# Altium schematic sheet NanoD1_Power.SchDoc
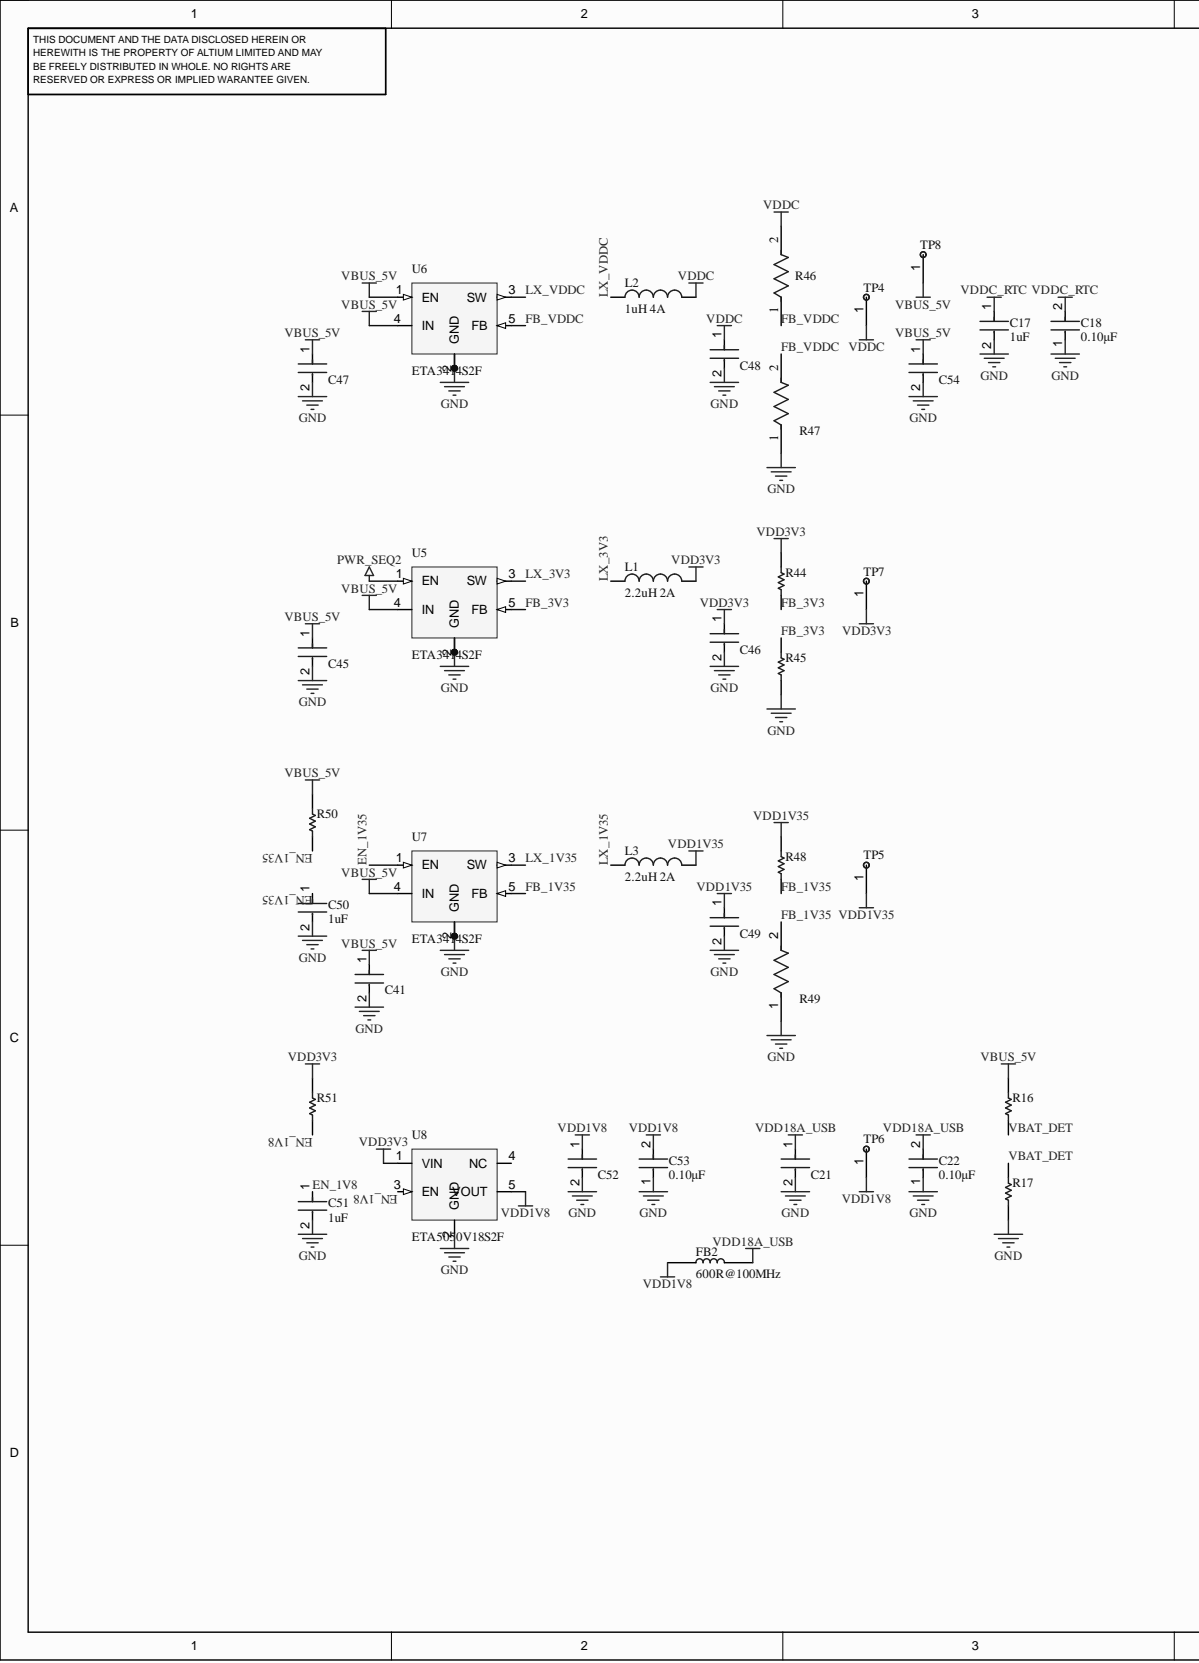
[diagram p0: NanoD1_Power.SchDoc, left]
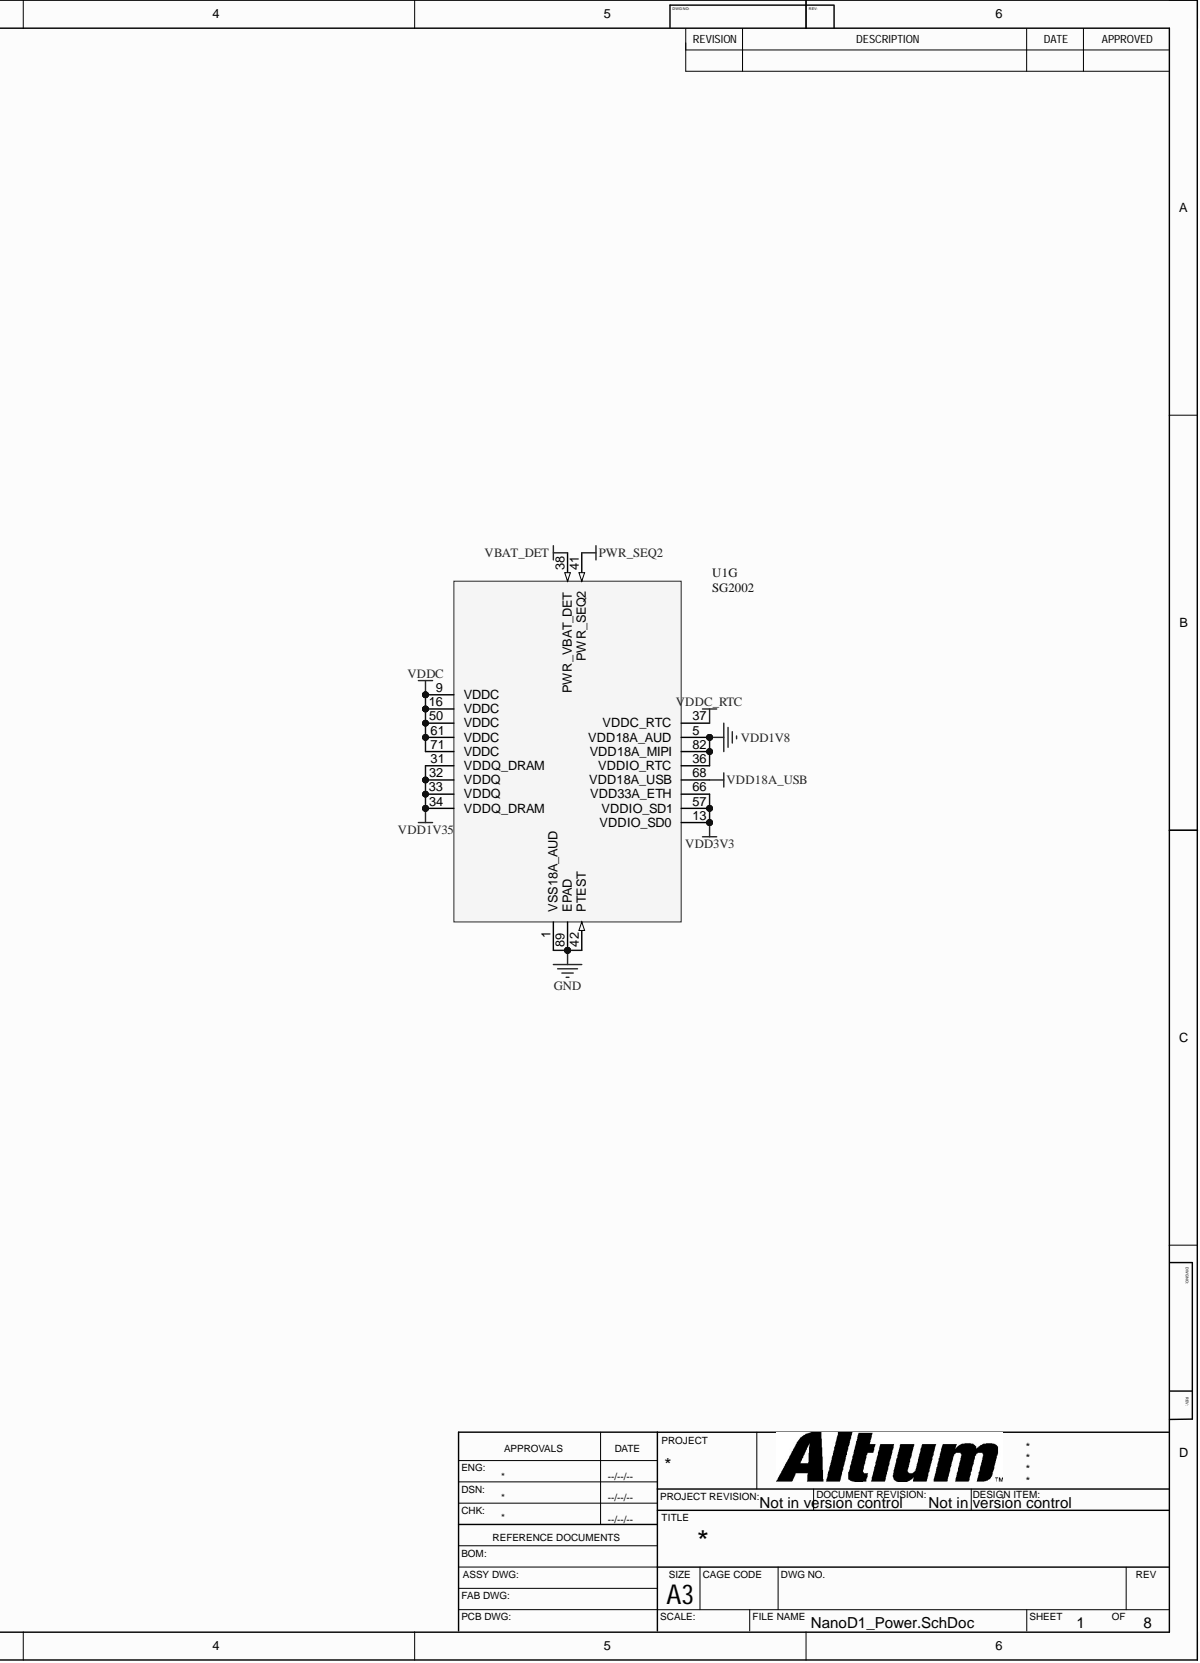
[diagram p1: NanoD1_Power.SchDoc, right]

## Parts
C17  1uF  [FP-GRM15-0_1-IPC_B]
C18  value 0.10μF  Capacitance: 0.10μF  [FP-GRM15-MFG]  (hidden comment: 100nF)
C21  10uF  [FP-GRM188-0_15-IPC_B]  (value not drawn)
C22  value 0.10μF  Capacitance: 0.10μF  [FP-GRM15-MFG]  (hidden comment: 100nF)
C41  22uF  [FP-GRM21B-0_15-MFG]  (value not drawn)
C45  22uF  [FP-GRM21B-0_15-MFG]  (value not drawn)
C46  22uF  [FP-GRM188-0_2-IPC_C]  (value not drawn)
C47  22uF  [FP-GRM21B-0_15-MFG]  (value not drawn)
C48  22uF  [FP-GRM188-0_2-IPC_C]  (value not drawn)
C49  22uF  [FP-GRM188-0_2-IPC_C]  (value not drawn)
C50  1uF  [FP-GRM15-0_1-IPC_B]
C51  1uF  [FP-GRM15-0_1-IPC_B]
C52  4.7uF  [FP-GRM155-0_15-MFG]  (value not drawn)
C53  value 0.10μF  Capacitance: 0.10μF  [FP-GRM15-MFG]  (hidden comment: 100nF)
C54  22uF  [FP-GRM21B-0_15-MFG]  (value not drawn)
FB2  600R@100MHz  [INDC1608X95N]
L1  2.2uH 2A  [FP-DFE252010C-MFG]
L2  value 1uH 4A  [FP-DFE252010C-MFG]  (hidden comment: 1.0uH 4A)
L3  2.2uH 2A  [FP-DFE252010C-MFG]
R16  30K 1%  [RESC1005X40X25ML05T10]  (value not drawn)
R17  10K 1%  [RESC1005X40X25ML05T10]  (value not drawn)
R44  100K 1%  [RESC1005X40X25ML05T10]  (value not drawn)
R45  22K6 1%  [RESC1005X40X25ML05T10]  (value not drawn)
R46  11K8 1%  [FP-RC0402-0_4-MFG]  (value not drawn)
R47  20K 1%  [FP-RC0402-0_4-MFG]  (value not drawn)
R48  15K 1%  [RESC1005X40X25ML05T10]  (value not drawn)
R49  12K 1%  [FP-RC0402-0_4-MFG]  (value not drawn)
R50  33K  [RESC1005X40X25ML05T10]  (value not drawn)
R51  33K  [RESC1005X40X25ML05T10]  (value not drawn)
TP4  TP  [TP-1.5mm]
TP5  TP  [TP-1.5mm]
TP6  TP  [TP-1.5mm]
TP7  TP  [TP-1.5mm]
TP8  TP  [TP-1.5mm]
U1  SG2002  [QFN88-9x9-0.35]  (part G)
U5  ETA3414S2F  [SOT23-5]
U6  ETA3414S2F  [SOT23-5]
U7  ETA3414S2F  [SOT23-5]
U8  ETA5050V18S2F  [SOT23-5]

## Nets
GND: C17.2 C18.1 C21.2 C22.1 C41.2 C45.2 C46.2 C47.2 C48.2 C49.2 C50.2 C51.2 C52.2 C53.1 C54.2 R17.1 R45.1 R47.1 R49.1 U1.1(VSS18A_AUD) U1.42(PTEST) U1.89(EPAD) U5.2(GND) U6.2(GND) U7.2(GND) U8.2(GND)
PWR_SEQ2: U1.41(PWR_SEQ2) U5.1(EN)
VBUS_5V: C41.1 C45.1 C47.1 C54.1 R16.2 R50.2 TP8.1 U5.4(IN) U6.1(EN) U6.4(IN) U7.4(IN)
VDD1V8: C52.1 C53.2 FB2.1 TP6.1 U1.5(VDD18A_AUD) U1.36(VDDIO_RTC) U1.82(VDD18A_MIPI) U8.5(VOUT)
VDD1V35: C49.1 L3.2 R48.2 TP5.1 U1.31(VDDQ_DRAM) U1.32(VDDQ) U1.33(VDDQ) U1.34(VDDQ_DRAM)
VDD3V3: C46.1 L1.2 R44.2 R51.2 TP7.1 U1.13(VDDIO_SD0) U1.57(VDDIO_SD1) U1.66(VDD33A_ETH) U8.1(VIN)
VDD18A_USB: C21.1 C22.2 FB2.2 U1.68(VDD18A_USB)
VDDC: C48.1 L2.2 R46.2 TP4.1 U1.9(VDDC) U1.16(VDDC) U1.50(VDDC) U1.61(VDDC) U1.71(VDDC)
VDDC_RTC: C17.1 C18.2 U1.37(VDDC_RTC)
EN_1V8: C51.1 R51.1 U8.3(EN)
EN_1V35: C50.1 R50.1 U7.1(EN)
FB_1V35: R48.1 R49.2 U7.5(FB)
FB_3V3: R44.1 R45.2 U5.5(FB)
FB_VDDC: R46.1 R47.2 U6.5(FB)
LX_1V35: L3.1 U7.3(SW)
LX_3V3: L1.1 U5.3(SW)
LX_VDDC: L2.1 U6.3(SW)
VBAT_DET: R16.1 R17.2 U1.38(PWR_VBAT_DET)

Not connected: U8 4(NC)

## Library data of the parts
C17 C50 C51 = CMP-2008-04556-2: CAP CER 1UF 10V X5R 0402; Manufacturer: Murata; Manufacturer Part Number: GRM155R61A105KE15D; Material: Ceramic; Temperature Coefficient: 15%; Tolerance: 10%; Automotive: No; Capacitance: 1uF; Category: Cap; Component Kind: Standard; ComponentLink2Description: Datasheet; ComponentLink2URL: https://search.murata.co.jp/Ceramy/image/img/A01X/G101/ENG/GRM155R61A105KE15-01A.pdf; Device Class L1: Passive Components; Device Class L2: Capacitors; Device Class L3: Ceramic Capacitors; Digikey Description: CAP CER 1UF 10V X5R 0402; Height: 0.55mm; Ipc Land Pattern Name: CAPC10050X50; Lead Free: Yes; Library Name: GRM155R61A105KE15D.IntLib; Mouser Description: 0402 1 uF 10 V ±10% Tolerance X5R Surface Mount Multilayer Ceramic Capacitor; Octopart: https://octopart.com/grm155r61a105ke15d-murata-197442; Package: GRM15-0.1; RoHS: TRUE; Temperature: -55°C to +85°C; Temperature Characteristic: X5R; Temperature Range High: +85°C; Temperature Range Low: -55°C; Value: 1uF; Voltage Rating: 10V
C18 C22 C53 = CMP-2100-03641-2: CAP CER 0.1UF 16V X7R 0402; Manufacturer: Murata; Manufacturer Part Number: GRM155R71C104KA88D; Material: Ceramic; Standoff Height: 0mm; Tolerance: 10%; Voltage Rating: 16Vdc; Category: Cap; Component Kind: Standard; ComponentLink2Description: Datasheet; ComponentLink2URL: https://search.murata.co.jp/Ceramy/image/img/A01X/G101/ENG/GRM155R71H471KA01-01A.pdf; Device Class L1: Passive Components; Device Class L2: Capacitors; Device Class L3: Ceramic Capacitors; Digikey Description: CAP CER 0.1UF 16V X7R 0402; Height: 0.55mm; Ipc Land Pattern Name: CAPC10050X219; Lead Free: yes; Library Name: GRM155R71C104KA88D.IntLib; Mouser Description: 0402 0.1 uF 16V ±10 % Tolerance X7R SMT Multilayer Ceramic Capacitor; Octopart: https://octopart.com/grm155r71c104ka88d-murata-196880; Package: GRM15; RoHS: TRUE; Temperature: -55°C to +125°C; Temperature Characteristic: X7R; Temperature Range High: +125°C; Temperature Range Low: -55°C
C21 = CMP-06035-003612-1: Chip Multilayer Ceramic Capacitors for General Purpose, 0603, 10uF, X5R, 15%, 20%, 10V; Capacitance: 10uF; Case Code (Imperial): 0603; Case Code (Metric): 1608; Case/Package: 0603; Component Kind: Standard; Depth: 0.8mm; Dielectric: X5R; Height: 0.8mm; Lead Free: Lead Free; Length: 1.6mm; Library Name: GRM188R61A106ME69D.IntLib; Material: Ceramic; Max Operating Temperature: 85°C; Min Operating Temperature: -55°C; Mount: Surface Mount; Package Quantity: 4000; Packaging: Tape and Reel; Termination: SMD/SMT; Thickness: 0.035inch; Tolerance: 20%; Voltage Rating: 10V; Voltage Rating (DC): 10V; Weight: 0.000222oz; Width: 0.8mm
C41 C45 C47 C54 = CMP-2000-06340-2: Chip Multilayer Ceramic Capacitors for General Purpose, 0805, 22uF, X5R, 15%, 20%, 10V; Capacitance: 22uF; Case Code (Imperial): 0805; Case Code (Metric): 2012; Case/Package: 0805; Component Kind: Standard; Depth: 1.25mm; Dielectric: X5R; Height: 1.25mm; Lead Free: Lead Free; Length: 2mm; Library Name: GRM21BR61A226ME51L.IntLib; Max Operating Temperature: 85°C; Min Operating Temperature: -55°C; Mount: Surface Mount; Package Quantity: 3000; Packaging: Tape and Reel; Radiation Hardening: No; REACH SVHC: No SVHC; Termination: SMD/SMT; Thickness: 0.055inch; Tolerance: 20%; Voltage Rating: 10V; Voltage Rating (DC): 10V; Weight: 0.000335oz; Width: 0.049inch
C46 C48 C49 = CMP-2000-06337-3: Chip Multilayer Ceramic Capacitors for General Purpose, 0603, 22uF, X5R, 15%, 20%, 10V; Capacitance: 22uF; Case Code (Imperial): 0603; Case Code (Metric): 1608; Case/Package: 0603; Component Kind: Standard; Depth: 0.8mm; Dielectric: X5R; Height: 0.8mm; Lead Free: Lead Free; Length: 1.6mm; Library Name: GRM188R61A226ME15D.IntLib; Material: Ceramic; Max Operating Temperature: 85°C; Min Operating Temperature: -55°C; Mount: Surface Mount; Package Quantity: 4000; Packaging: Tape and Reel; REACH SVHC: No SVHC; Termination: SMD/SMT; Thickness: 0.039inch; Tolerance: 20%; Voltage Rating: 10V; Voltage Rating (DC): 10V; Weight: 0.000222oz; Width: 0.8mm
C52 = CMP-06035-003573-1: Chip Multilayer Ceramic Capacitors for General Purpose, 0402, 4.7uF, X5R, 15%, 20%, 10V; Capacitance: 4.7uF; Case Code (Imperial): 0402; Case Code (Metric): 1005; Case/Package: 0402; Component Kind: Standard; Library Name: GRM155R61A475MEAAD.IntLib; Max Operating Temperature: 85°C; Min Operating Temperature: -55°C; Mount: Surface Mount; Packaging: Tape and Reel; Tolerance: 20%; Voltage Rating: 10V
FB2 = MPZ1608S601ATA00: 600 Ohms @ 100 MHz 1 Power Line Ferrite Bead 0603 (1608 Metric) 1A 150mOhm; MF: TDK; Check_prices: https://www.snapeda.com/parts/MPZ1608S601ATA00/TDK/view-part/?ref=eda; Component Kind: Standard; Library Name: MPZ1608S601ATA00.IntLib; MP: MPZ1608S601ATA00; Package: 0603 TDK; SnapEDA_Link: https://www.snapeda.com/parts/MPZ1608S601ATA00/TDK/view-part/?ref=snap; Value: 600R@100MHz
L1-L3 = Inductor: Code_IEC: 1005; Code_JEITA: 0402; Component Kind: Standard; Description: Inductor; LatestRevisionDate: 29-May-2009; LatestRevisionNote: IPC-7351 Footprint Added.; Library Name: Miscellaneous Devices.IntLib; PackageDescription: Chip Inductor; PackageReference: 0402-A; Published: 8-Jun-2000; Signal Integrity: Inductor; Simulation: INDUCTOR; Publisher: Altium Limited
  L1 L3: Value: 2.2uH 2A
R16 = CMP-2002-07602-1: Manufacturer: Yageo / Phycomp; Part Number: RC0402FR-0730KL; Component Kind: Standard; Library Name: RC0402FR-0730KL.IntLib
R17 = CMP-2002-07353-1: Manufacturer: Yageo / Phycomp; Part Number: RC0402FR-0710KL; Component Kind: Standard; Library Name: RC0402FR-0710KL.IntLib
R44 = CMP-2002-07343-1: Manufacturer: Yageo / Phycomp; Part Number: RC0402FR-07100KL; Component Kind: Standard; Library Name: RC0402FR-07100KL.IntLib
R45 = CMP-2002-07516-1: Manufacturer: Yageo; Part Number: RC0402FR-0722K6L; Component Kind: Standard; Library Name: RC0402FR-0722K6L.IntLib
R46 = CMP-2002-07909-2: NexarPartId: 9293467; Max Power Dissipation: 63mW; Resistance: 11.8kΩ; Temperature Coefficient: 100ppm/°C; Voltage Rating: 50V; Case Code (Imperial): 0402; Case Code (Metric): 1005; Case/Package: 0402; Component Kind: Standard; Composition: Thick Film; Contact Plating: Lead, Tin; Depth: 500µm; Features: Moisture Resistant; Height: 400µm; Lead Free: Lead Free; Length: 1mm; Library Name: RC0402FR-0711K8L.IntLib; Max Operating Temperature: 155°C; Military Standard: Not; Min Operating Temperature: -55°C; Number of Terminations: 2; Packaging: Tape & Reel; Power Rating: 63mW; Radiation Hardening: No; RoHS: Compliant; Schedule B: 8533210045, 8533210045|8533210045, 8533210045|8533210045|8533210045, 8533210045|8533210045|8533210045|8533210045; Termination: SMD/SMT; Tolerance: 1%; Voltage Rating (DC): 50V; Weight: 652.039032µg; Width: 508µm
R47 = CMP-2002-07501-2: NexarPartId: 39794396; Max Power Dissipation: 63mW; Power Rating: 62.5mW; Resistance: 20kΩ; Temperature Coefficient: 100ppm/°C; Voltage Rating: 50V; Case Code (Imperial): 0402; Case Code (Metric): 1005; Case/Package: 0402; Component Kind: Standard; Composition: Thick Film; Depth: 500µm; Features: Moisture Resistant; Height: 350µm; Lead Free: Lead Free; Length: 1mm; Library Name: RC0402FR-0720KL.IntLib; Max Operating Temperature: 155°C; Military Standard: Not; Min Operating Temperature: -55°C; Number of Pins: 2; Number of Terminations: 2; Packaging: Tape & Reel; REACH SVHC: No SVHC; RoHS: Compliant; Schedule B: 8533210045, 8533210045|8533210045|8533210045|8533210045|8533210045|8533210045|8533210045|8533210045|8533210045|8533210045|8533210045|8533210045|8533210045|8533210045|8533210045|8533210045|8533210045|8533210045|8533210045|8533210045|8533210045|8533210045|8533210045|85; Termination: SMD/SMT; Tolerance: 1%; Voltage Rating (DC): 50V; Width: 500µm
R48 = CMP-2002-07410-1: Manufacturer: Yageo / Phycomp; Part Number: RC0402FR0715KL; Component Kind: Standard; Library Name: RC0402FR-0715KL.IntLib
R49 = CMP-2002-07378-2: RES SMD 12K OHM 1% 1/16W 0402; Max Power Dissipation: 63mW; Power Rating: 62.5mW; Resistance: 12kR; Temperature Coefficient: 100ppm/°C; Voltage Rating: 50V; Case Code (Imperial): 0402; Case Code (Metric): 1005; Case/Package: 0402; Component Kind: Standard; Construction: Rectangular; Depth: 0.5mm; Features: Moisture Resistant; Height: 0.016inch; Lead Free: Lead Free; Length: 1mm; Library Name: RC0402FR-0712KL.IntLib; Max Operating Temperature: 155°C; Military Standard: Not; Min Operating Temperature: -55°C; Number of Terminations: 2; Package Quantity: 1; Packaging: Tape and Reel; REACH SVHC: No SVHC; Resistance Tolerance: 1%; Resistor Type: Thick Film; RoHS Compliant: Yes; Series: RC; Size Code: 0402; Termination: SMD/SMT; Tolerance: 1%; Weight: 2.3E-05oz; Width: 0.02inch
R50 R51 = CMP-2002-07617-1: Manufacturer: Yageo / Phycomp; Part Number: RC0402FR-0733KL; Component Kind: Standard; Library Name: RC0402FR-0733KL.IntLib
TP4-TP8 = TestPoint: Test point, 1.5 mm exposed pad; Component Kind: Standard; Library Name: NanoD1.SchLib
U1 = SG2002: RISC-V C906 / Cortex-A53 SoC, 256MB DDR3 SiP, QFN88 9x9 0.35mm; Component Kind: Standard; Library Name: NanoD1.SchLib
U5-U7 = ETA3414: 1.5A 3MHz synchronous step-down converter, SOT23-5; Component Kind: Standard; Library Name: NanoD1.SchLib
U8 = ETA5050V18: 1.8V fixed LDO, SOT23-5; Component Kind: Standard; Library Name: NanoD1.SchLib
